# Altium schematic sheet iso_power.SchDoc
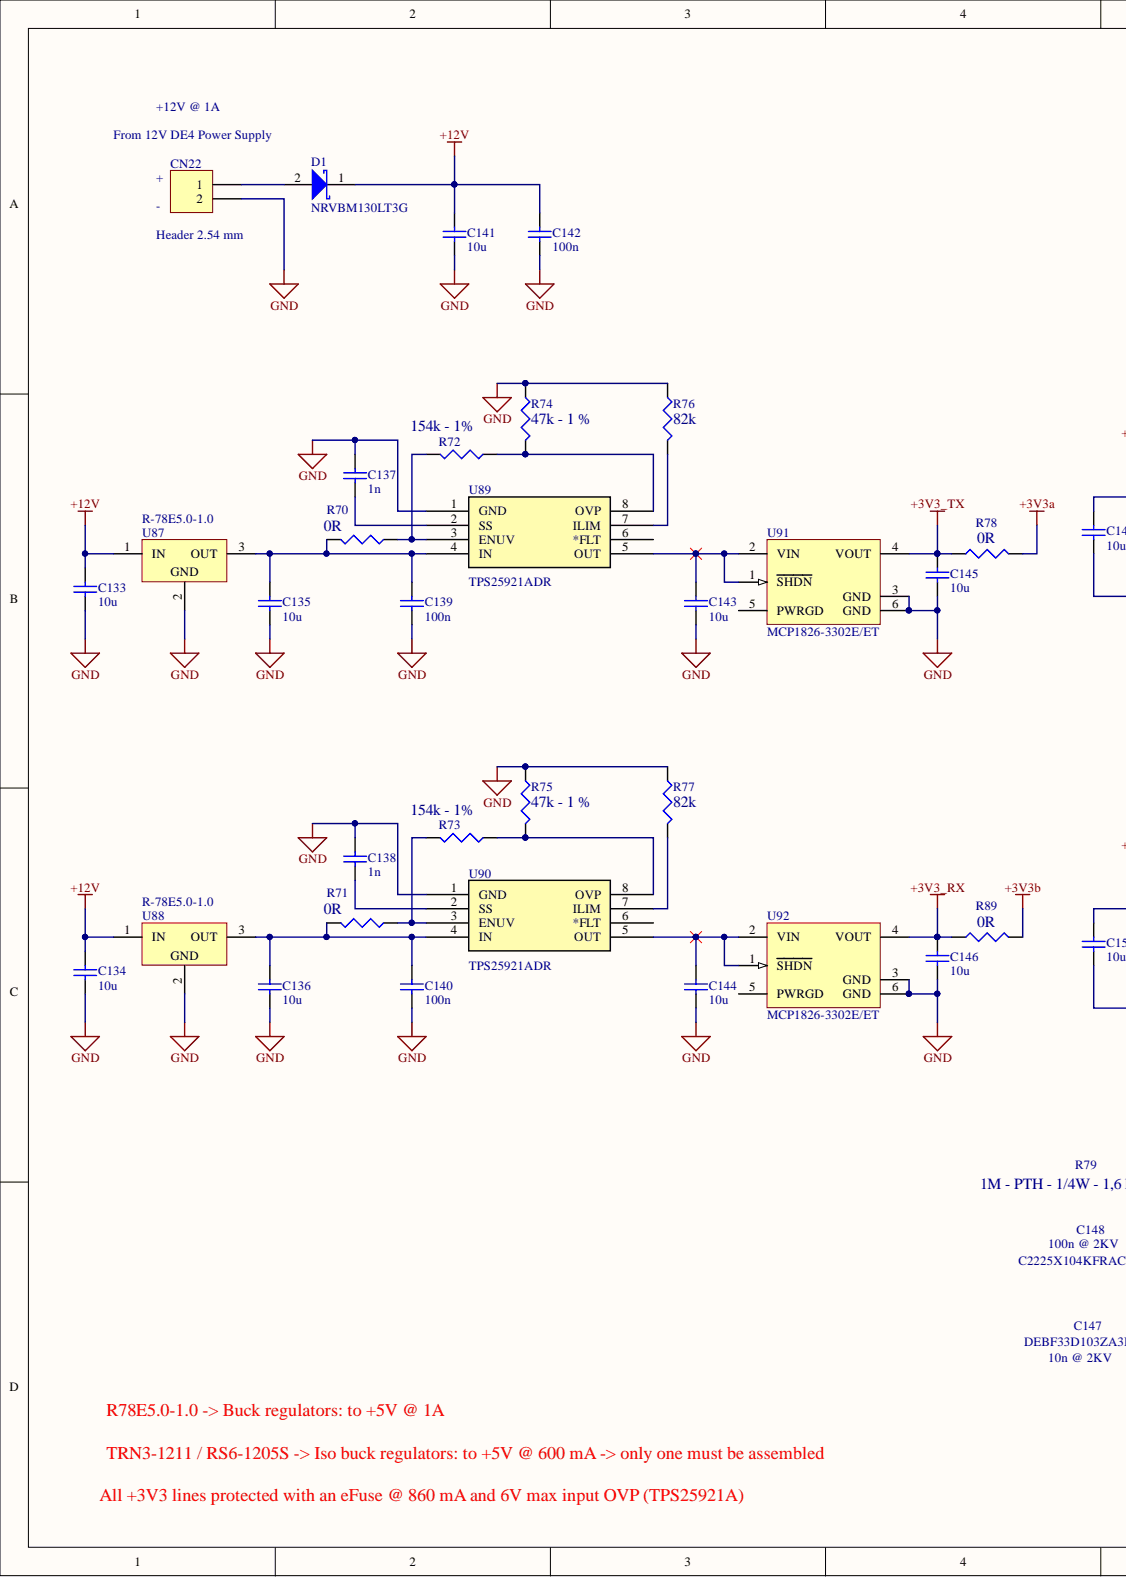
[diagram p0: iso_power.SchDoc, left]
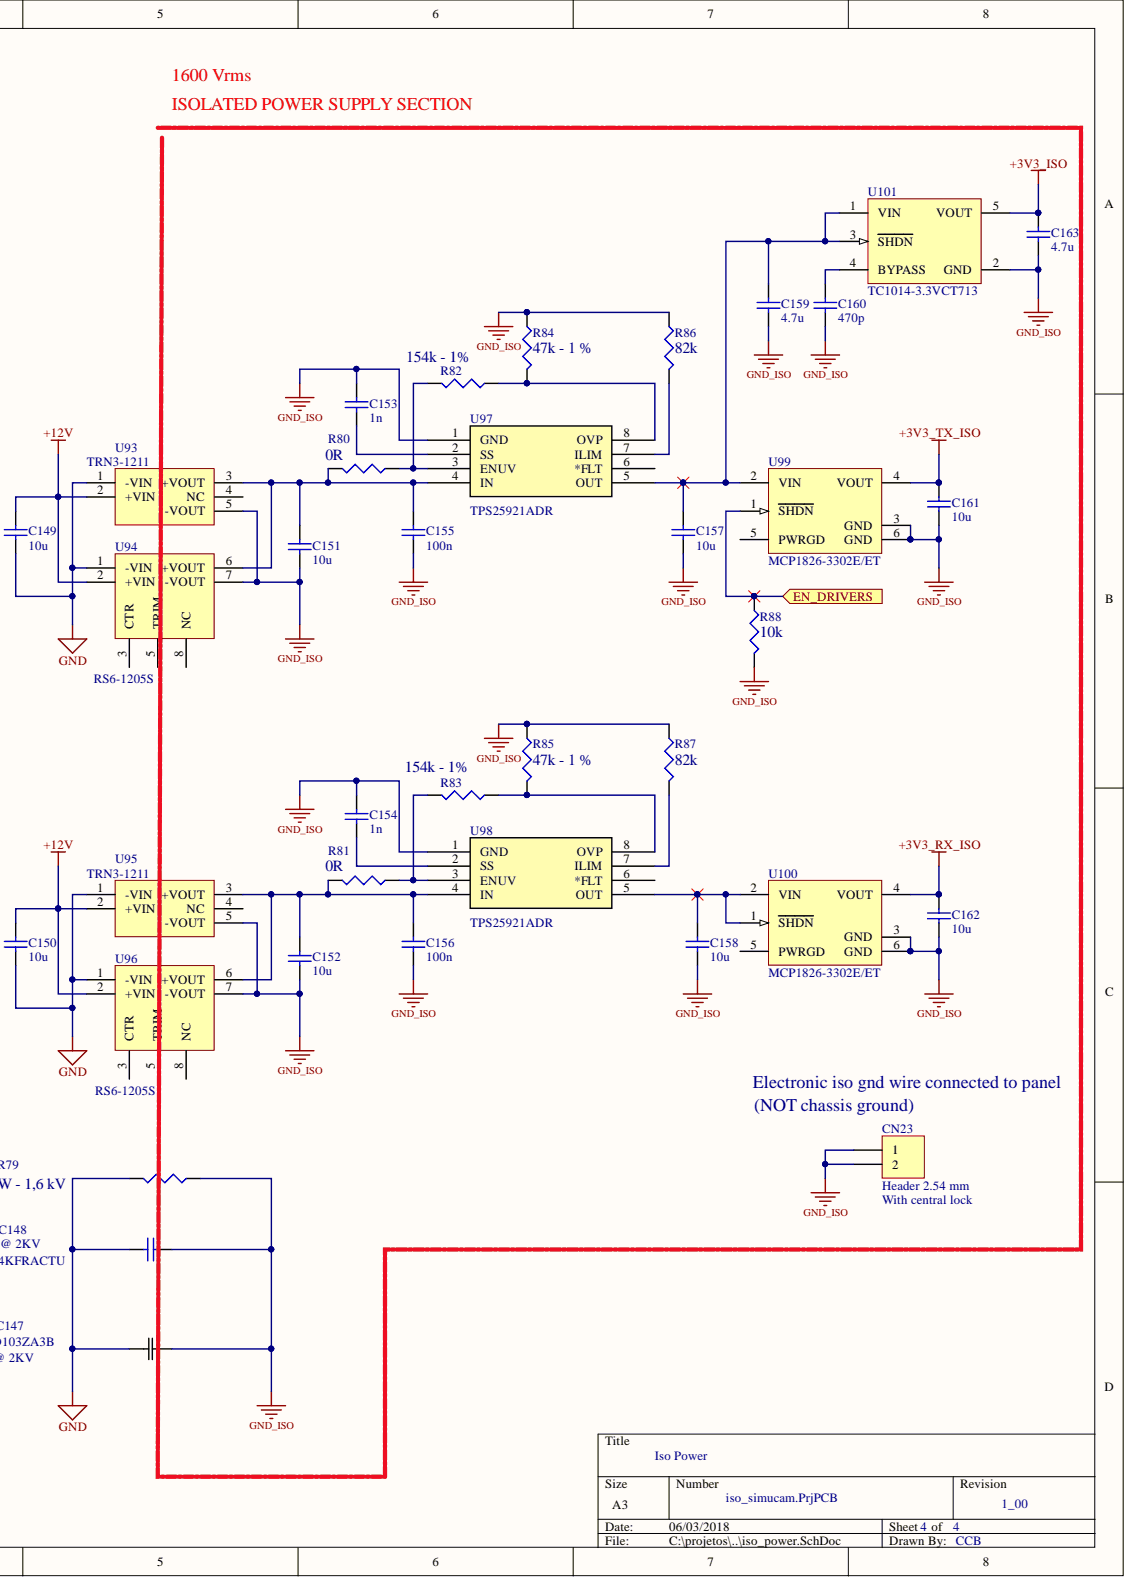
[diagram p1: iso_power.SchDoc, right]

## Parts
C133  Valor: 10u  [0603 - CAP - montagem na maquina]  (hidden comment: Capacitor Cerâmico SMD)
C134  Valor: 10u  [0603 - CAP - montagem na maquina]  (hidden comment: Capacitor Cerâmico SMD)
C135  Valor: 10u  [0603 - CAP - montagem na maquina]  (hidden comment: Capacitor Cerâmico SMD)
C136  Valor: 10u  [0603 - CAP - montagem na maquina]  (hidden comment: Capacitor Cerâmico SMD)
C137  Valor: 1n  [0402-cap]  (hidden comment: Capacitor Cerâmico SMD)
C138  Valor: 1n  [0402-cap]  (hidden comment: Capacitor Cerâmico SMD)
C139  Valor: 100n  [0402-cap]  (hidden comment: Capacitor Cerâmico SMD)
C140  Valor: 100n  [0402-cap]  (hidden comment: Capacitor Cerâmico SMD)
C141  Valor: 10u  [0603 - CAP - montagem na maquina]  (hidden comment: Capacitor Cerâmico SMD)
C142  Valor: 100n  [0402-cap]  (hidden comment: Capacitor Cerâmico SMD)
C143  Valor: 10u  [0603 - CAP - montagem na maquina]  (hidden comment: Capacitor Cerâmico SMD)
C144  Valor: 10u  [0603 - CAP - montagem na maquina]  (hidden comment: Capacitor Cerâmico SMD)
C145  Valor: 10u  [0603 - CAP - montagem na maquina]  (hidden comment: Capacitor Cerâmico SMD)
C146  Valor: 10u  [0603 - CAP - montagem na maquina]  (hidden comment: Capacitor Cerâmico SMD)
C147  DEBF33D103ZA3B  [CAP_10X4]
C148  Capacitor Cerâmico SMD  [C2225]  (value not drawn)
C149  Valor: 10u  [0603 - CAP - montagem na maquina]  (hidden comment: Capacitor Cerâmico SMD)
C150  Valor: 10u  [0603 - CAP - montagem na maquina]  (hidden comment: Capacitor Cerâmico SMD)
C151  Valor: 10u  [0603 - CAP - montagem na maquina]  (hidden comment: Capacitor Cerâmico SMD)
C152  Valor: 10u  [0603 - CAP - montagem na maquina]  (hidden comment: Capacitor Cerâmico SMD)
C153  Valor: 1n  [0402-cap]  (hidden comment: Capacitor Cerâmico SMD)
C154  Valor: 1n  [0402-cap]  (hidden comment: Capacitor Cerâmico SMD)
C155  Valor: 100n  [0402-cap]  (hidden comment: Capacitor Cerâmico SMD)
C156  Valor: 100n  [0402-cap]  (hidden comment: Capacitor Cerâmico SMD)
C157  Valor: 10u  [0603 - CAP - montagem na maquina]  (hidden comment: Capacitor Cerâmico SMD)
C158  Valor: 10u  [0603 - CAP - montagem na maquina]  (hidden comment: Capacitor Cerâmico SMD)
C159  Valor: 4.7u  [0402-cap]  (hidden comment: Capacitor Cerâmico SMD)
C160  Valor: 470p  [0402-cap]  (hidden comment: Capacitor Cerâmico SMD)
C161  Valor: 10u  [0603 - CAP - montagem na maquina]  (hidden comment: Capacitor Cerâmico SMD)
C162  Valor: 10u  [0603 - CAP - montagem na maquina]  (hidden comment: Capacitor Cerâmico SMD)
C163  Valor: 4.7u  [0402-cap]  (hidden comment: Capacitor Cerâmico SMD)
CN22  Header 2  Valor: Header 2.54 mm  [HDR1X2]
CN23  Header 2  Valor: Header 2.54 mm  [HDR1X2]
D1  NRVBM130LT3G  [D0-216AA]
R70  Valor: 0R  [0402-res]  (hidden comment: Res3)
R71  Valor: 0R  [0402-res]  (hidden comment: Res3)
R72  Valor: 154k - 1%  [0402-res]  (hidden comment: Res3)
R73  Valor: 154k - 1%  [0402-res]  (hidden comment: Res3)
R74  Res3  [0402-res]  (value not drawn)
R75  Res3  [0402-res]  (value not drawn)
R76  Valor: 82k  [0402-res]  (hidden comment: Res3)
R77  Valor: 82k  [0402-res]  (hidden comment: Res3)
R78  Valor: 0R  [0402-res]  (hidden comment: Res3)
R79  Res3  [CR25]  (value not drawn)
R80  Valor: 0R  [0402-res]  (hidden comment: Res3)
R81  Valor: 0R  [0402-res]  (hidden comment: Res3)
R82  Valor: 154k - 1%  [0402-res]  (hidden comment: Res3)
R83  Valor: 154k - 1%  [0402-res]  (hidden comment: Res3)
R84  Res3  [0402-res]  (value not drawn)
R85  Res3  [0402-res]  (value not drawn)
R86  Valor: 82k  [0402-res]  (hidden comment: Res3)
R87  Valor: 82k  [0402-res]  (hidden comment: Res3)
R88  Valor: 10k  [0402-res]  (hidden comment: Res3)
R89  Valor: 0R  [0402-res]  (hidden comment: Res3)
U87  R-78E5.0-1.0  [TO-220]
U88  R-78E5.0-1.0  [TO-220]
U89  TPS25921ADR  [D8]
U90  TPS25921ADR  [D8]
U91  MCP1826-3302E/ET  [DDPAK-ET5_N]
U92  MCP1826-3302E/ET  [DDPAK-ET5_N]
U93  TRN3-1211  [TRN3]
U94  RS6-1205S  [RS6]
U95  TRN3-1211  [TRN3]
U96  RS6-1205S  [RS6]
U97  TPS25921ADR  [D8]
U98  TPS25921ADR  [D8]
U99  MCP1826-3302E/ET  [DDPAK-ET5_N]
U100  MCP1826-3302E/ET  [DDPAK-ET5_N]
U101  TC1014-3.3VCT713  [SOT-23-CT5_N]

## Ports
EN_DRIVERS

## Nets
+3V3_ISO: C163.2 U101.5(VOUT)
+3V3_RX: C146.2 R89.1 U92.4(VOUT)
+3V3_RX_ISO: C162.2 U100.4(VOUT)
+3V3_TX: C145.2 R78.1 U91.4(VOUT)
+3V3_TX_ISO: C161.2 U99.4(VOUT)
+3V3a: R78.2
+3V3b: R89.2
+12V: C133.2 C134.2 C141.2 C142.2 C149.2 C150.2 D1.1 U87.1 U88.1 U93.2(+VIN) U94.2(+VIN) U95.2(+VIN) U96.2(+VIN)
GND: C133.1 C134.1 C135.1 C136.1 C137.1 C138.1 C139.1 C140.1 C141.1 C142.1 C143.1 C144.1 C145.1 C146.1 C147.1 C148.1 C149.1 C150.1 CN22.2 R74.1 R75.1 R76.1 R77.1 R79.2 U87.2 U88.2 U89.1(GND) U90.1(GND) U91.3(GND) U91.6(GND) U92.3(GND) U92.6(GND) U93.1(-VIN) U94.1(-VIN) U95.1(-VIN) U96.1(-VIN)
GND_ISO: C147.2 C148.2 C151.1 C152.1 C153.1 C154.1 C155.1 C156.1 C157.1 C158.1 C159.1 C160.1 C161.1 C162.1 C163.1 CN23.1 CN23.2 R79.1 R84.1 R85.1 R86.1 R87.1 R88.2 U93.5(-VOUT) U94.7(-VOUT) U95.5(-VOUT) U96.7(-VOUT) U97.1(GND) U98.1(GND) U99.3(GND) U99.6(GND) U100.3(GND) U100.6(GND) U101.2(GND)
EN_DRIVERS: R88.1 U99.1(SHDN) ; port EN_DRIVERS
NetC135_2: C135.2 C139.2 R70.2 U87.3 U89.4(IN)
NetC136_2: C136.2 C140.2 R71.2 U88.3 U90.4(IN)
NetC137_2: C137.2 U89.2(SS)
NetC138_2: C138.2 U90.2(SS)
NetC143_2: C143.2 U89.5(OUT) U91.1(SHDN) U91.2(VIN)
NetC144_2: C144.2 U90.5(OUT) U92.1(SHDN) U92.2(VIN)
NetC151_2: C151.2 C155.2 R80.2 U93.3(+VOUT) U94.6(+VOUT) U97.4(IN)
NetC152_2: C152.2 C156.2 R81.2 U95.3(+VOUT) U96.6(+VOUT) U98.4(IN)
NetC153_2: C153.2 U97.2(SS)
NetC154_2: C154.2 U98.2(SS)
NetC157_2: C157.2 C159.2 U97.5(OUT) U99.2(VIN) U101.1(VIN) U101.3(SHDN)
NetC158_2: C158.2 U98.5(OUT) U100.1(SHDN) U100.2(VIN)
NetC160_2: C160.2 U101.4(BYPASS)
NetCN22_1: CN22.1 D1.2
NetR70_1: R70.1 R72.2 U89.3(ENUV)
NetR71_1: R71.1 R73.2 U90.3(ENUV)
NetR72_1: R72.1 R74.2 U89.8(OVP)
NetR73_1: R73.1 R75.2 U90.8(OVP)
NetR76_2: R76.2 U89.7(ILIM)
NetR77_2: R77.2 U90.7(ILIM)
NetR80_1: R80.1 R82.2 U97.3(ENUV)
NetR81_1: R81.1 R83.2 U98.3(ENUV)
NetR82_1: R82.1 R84.2 U97.8(OVP)
NetR83_1: R83.1 R85.2 U98.8(OVP)
NetR86_2: R86.2 U97.7(ILIM)
NetR87_2: R87.2 U98.7(ILIM)

Not connected: U89 6(*FLT); U90 6(*FLT); U91 5(PWRGD); U92 5(PWRGD); U93 4(NC); U94 3(CTR) 5(TRIM) 8(NC); U95 4(NC); U96 3(CTR) 5(TRIM) 8(NC); U97 6(*FLT); U98 6(*FLT); U99 5(PWRGD); U100 5(PWRGD)

## Library data of the parts
C147 = DEBF33D103ZA3B: Imported; Built_By: EMA_UL_Team; C: Copyright (C) 2015 Accelerated Designs. All rights reserved; Valor: 10n @ 2KV; Vendor: Murata; Component Kind: Standard; Library Name: debf33d103za3b.IntLib; Manufacturer_Part_Number: DEBF33D103ZA3B; Value: Value
C148 = Capacitor Cerâmico SMD: Part Number: C2225X104KFRACTU; Valor: 100n @ 2KV; Component Kind: Standard; Description: Capacitor Cerâmico SMD; Library Name: Miscellaneous Devices.IntLib
CN22 CN23 = Header 2: Header, 2-Pin; Component Kind: Standard; LatestRevisionDate: 17-Jul-2002; LatestRevisionNote: Re-released for DXP Platform.; Library Name: Miscellaneous Connectors.IntLib; Signal Integrity: Connector; Publisher: Altium Limited
D1 = NRVBM130LT3G: Surface Mount  Schottky Power Rectifier - 1.0 AMPERES, 30 VOLTS; Fabricante: On Semi; Component Kind: Standard; Encapsulamento: D0-216AA; Library Name: on semi diodes.IntLib
R70-R73 R76-R78 R80-R83 R86-R89 = Res3: Resistor; Grupo: 01; Component Kind: Standard; Library Name: Miscellaneous Devices.IntLib
R74 R75 R84 R85 = Res3: Resistor; Grupo: 01; Valor: 47k - 1 %; Component Kind: Standard; Library Name: Miscellaneous Devices.IntLib
R79 = Res3: Resistor; Part number: VR25000001004FA100; Grupo: 01; Valor: 1M - PTH - 1/4W - 1,6 kV; Component Kind: Standard; Library Name: Miscellaneous Devices.IntLib
U87 U88 = R-78E5.0-1.0: 3-Terminal Positive dc-dc regulator - 5V @ 1A; Valor: 5V ; 1A; Component Kind: Standard; Encapsulamento: TO-220; Library Name: dc_dc.IntLib
U89 U90 U97 U98 = TPS25921ADR: Imported; Built_By: EMA_UL_Team; C: Copyright (C) 2016 Accelerated Designs. All rights reserved; Vendor: Texas Instruments; Component Kind: Standard; Library Name: tps25921adr.IntLib; Manufacturer_Part_Number: TPS25921ADR; RefDes: RefDes; Type: Type; Value: Value
U91 U92 U99 U100 = MCP1826-3302E/ET: 1000 mA, Low Voltage, Low Quiescent Current LDO Regulator, 5-Pin DDPAK, Extended Temperature; Manufacturer: Microchip; Component Kind: Standard; ComponentLink1Description: Manufacturer URL; ComponentLink1URL: http://www.microchip.com/; ComponentLink2Description: Datasheet; ComponentLink2URL: http://ww1.microchip.com/downloads/en/DeviceDoc/22057a.pdf; ComponentLink3Description: Package Specification; ComponentLink3URL: http://www.microchip.com/stellent/groups/techpub_sg/documents/packagingspec/en012702.pdf; DatasheetVersion: revA, Aug-2007; Library Name: Microchip Power Management Line Regulator.IntLib; Mounting Technology: Surface Mount; PackageDescription: 5-Lead Plastic (ET) [DDPAK]; PackageReference: DDPAK-ET5; PackageVersion: revBB, Aug-2009; PartNumber: MCP1826-3302E/ET; Supplier 1: Digi-Key; Supplier 2: Newark; Supplier 3: Mouser; Supplier Part Number 1: MCP1826-3302E/ET-ND; Supplier Part Number 2: 27M0017; Supplier Part Number 3: 579-MCP1826-3302E/ET
U93 U95 = TRN3-1211: 4-Terminal Positive dc-dc iso regulator - 5V @ 0,6A; Valor: 5V ; 0,6A; Component Kind: Standard; Encapsulamento: TRN3; Library Name: dc_dc.IntLib
U94 U96 = RS6-1205S: 8-Terminal Positive dc-dc iso regulator - 5V @ 1,2A; Valor: 5V ; 1,2A; Component Kind: Standard; Encapsulamento: RS6; Library Name: dc_dc.IntLib
U101 = TC1014-3.3VCT713: 50 mA, 100 mA and 150 mA CMOS LDOs with Active-Low Shutdown and Reference Bypass, 5-Pin SOT-23, Extended Temperature, Tape and Reel; Manufacturer: Microchip; Code_IPC: SOT23-5; Component Kind: Standard; ComponentLink1Description: Manufacturer URL; ComponentLink1URL: http://www.microchip.com/; ComponentLink2Description: Datasheet; ComponentLink2URL: http://ww1.microchip.com/downloads/en/DeviceDoc/21335e.pdf; ComponentLink3Description: Package Specification; ComponentLink3URL: http://www.microchip.com/stellent/groups/techpub_sg/documents/packagingspec/en012702.pdf; DatasheetVersion: revE, Feb-2007; Library Name: Microchip Power Management Line Regulator.IntLib; Mounting Technology: Surface Mount; PackageDescription: 5-Lead Plastic Small Outline Transistor (CT) [SOT-23]; PackageReference: SOT-23-CT5; PackageVersion: revBB, Aug-2009; PartNumber: TC1014-3.3VCT713; Supplier 1: Digi-Key; Supplier 2: Digi-Key; Supplier 3: Digi-Key; Supplier 4: Farnell; Supplier 5: Farnell; Supplier 6: Newark; Supplier 7: Mouser; Supplier Part Number 1: TC1014-3.3VCT713TR-ND; Supplier Part Number 2: TC1014-3.3VCT713CT-ND; Supplier Part Number 3: TC1014-3.3VCT713DKR-ND; Supplier Part Number 4: 1331502RL; Supplier Part Number 5: 1331502; Supplier Part Number 6: 61K3534; Supplier Part Number 7: 579-TC1014-3.3VCT713
C133-C146 C149-C163 = Capacitor Cerâmico SMD: Component Kind: Standard; Description: Capacitor Cerâmico SMD; Library Name: Miscellaneous Devices.IntLib
